# Altium schematic sheet Sensor.SchDoc
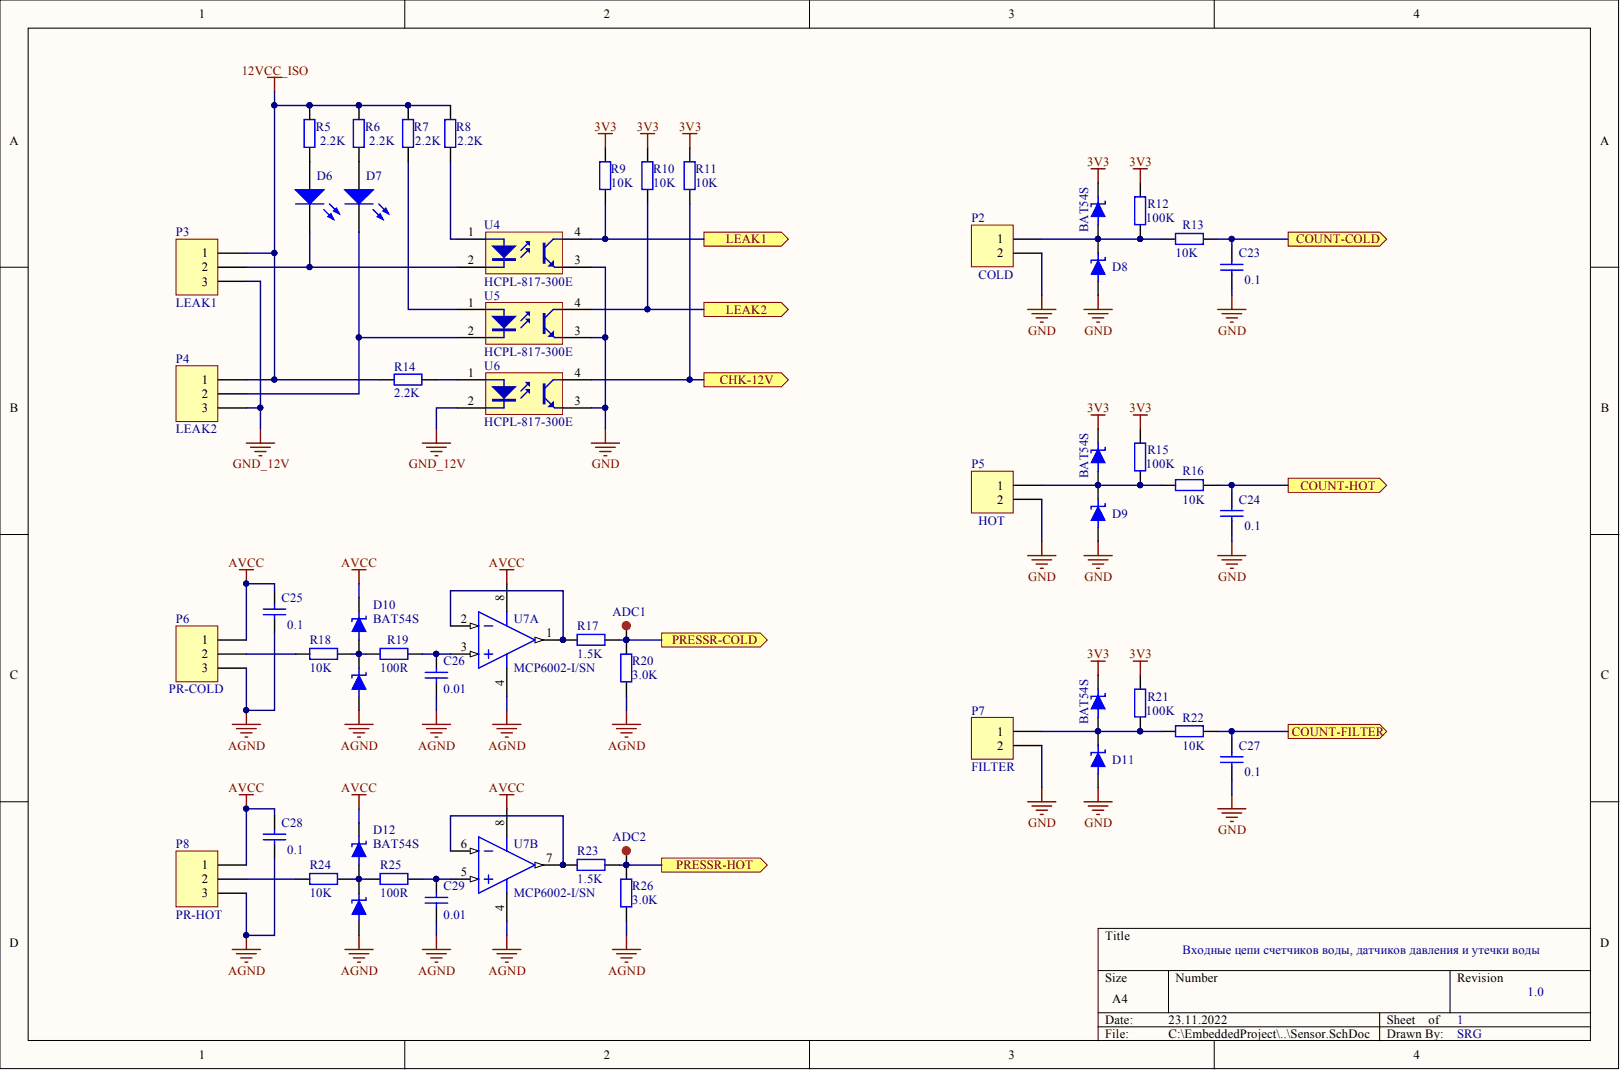
[diagram p0: Sensor.SchDoc]

## Parts
ADC1  Control mark  [Control mark]  (value not drawn)
ADC2  Control mark  [Control mark]  (value not drawn)
C23  value 0.1  [C1206]  (hidden comment: Cap)
C24  value 0.1  [C1206]  (hidden comment: Cap)
C25  value 0.1  [C1206]  (hidden comment: Cap)
C26  value 0.01  [C1206]  (hidden comment: Cap)
C27  value 0.1  [C1206]  (hidden comment: Cap)
C28  value 0.1  [C1206]  (hidden comment: Cap)
C29  value 0.01  [C1206]  (hidden comment: Cap)
D6  ALR1  [LED1206 - RED]  (value not drawn)
D7  ALR2  [LED1206 - RED]  (value not drawn)
D8  BAT54S  [SOT23]
D9  BAT54S  [SOT23]
D10  BAT54S  [SOT23]
D11  BAT54S  [SOT23]
D12  BAT54S  [SOT23]
P2  COLD  [KF141V-2]
P3  LEAK1  [KF141V-3]
P4  LEAK2  [KF141V-3]
P5  HOT  [KF141V-2]
P6  PR-COLD  [KF141V-3]
P7  FILTER  [KF141V-2]
P8  PR-HOT  [KF141V-3]
R5  value 2.2K  [R1206]  (hidden comment: Res2)
R6  value 2.2K  [R1206]  (hidden comment: Res2)
R7  value 2.2K  [R1206]  (hidden comment: Res2)
R8  value 2.2K  [R1206]  (hidden comment: Res2)
R9  value 10K  [R1206]  (hidden comment: Res2)
R10  value 10K  [R1206]  (hidden comment: Res2)
R11  value 10K  [R1206]  (hidden comment: Res2)
R12  value 100K  [R1206]  (hidden comment: Res2)
R13  value 10K  [R1206]  (hidden comment: Res2)
R14  value 2.2K  [R1206]  (hidden comment: Res2)
R15  value 100K  [R1206]  (hidden comment: Res2)
R16  value 10K  [R1206]  (hidden comment: Res2)
R17  value 1.5K  [R1206]  (hidden comment: Res2)
R18  value 10K  [R1206]  (hidden comment: Res2)
R19  value 100R  [R1206]  (hidden comment: Res2)
R20  value 3.0K  [R1206]  (hidden comment: Res2)
R21  value 100K  [R1206]  (hidden comment: Res2)
R22  value 10K  [R1206]  (hidden comment: Res2)
R23  value 1.5K  [R1206]  (hidden comment: Res2)
R24  value 10K  [R1206]  (hidden comment: Res2)
R25  value 100R  [R1206]  (hidden comment: Res2)
R26  value 3.0K  [R1206]  (hidden comment: Res2)
U4  HCPL-817-300E  [4-SMD]
U5  HCPL-817-300E  [4-SMD]
U6  HCPL-817-300E  [4-SMD]
U7  MCP6002-I/SN  [SOIC-SN8_N]  (parts A,B)

## Ports
CHK-12V, COUNT-COLD, COUNT-FILTER, COUNT-HOT, LEAK1, LEAK2, PRESSR-COLD, PRESSR-HOT

## Nets
3V3: D8.2 D9.2 D11.2 R9.2 R10.2 R11.2 R12.1 R15.1 R21.1
12VCC_ISO: P3.1 P4.1 R5.2 R6.2 R7.2 R8.2 R14.2
AGND: C25.2 C26.1 C28.2 C29.1 D10.1 D12.1 P6.3 P8.3 R20.1 R26.1 U7.4
AVCC: C25.1 C28.1 D10.2 D12.2 P6.1 P8.1 U7.8
GND: C23.2 C24.2 C27.2 D8.1 D9.1 D11.1 P2.2 P5.2 P7.2 U4.3 U5.3 U6.3
GND_12V: P3.3 P4.3 U6.2
CHK-12V: R11.1 U6.4 ; port CHK-12V
COUNT-COLD: C23.1 R13.1 ; port COUNT-COLD
COUNT-FILTER: C27.1 R22.1 ; port COUNT-FILTER
COUNT-HOT: C24.1 R16.1 ; port COUNT-HOT
LEAK1: R9.1 U4.4 ; port LEAK1
LEAK2: R10.1 U5.4 ; port LEAK2
PRESSR-COLD: ADC1.1 R17.2 R20.2 ; port PRESSR-COLD
PRESSR-HOT: ADC2.1 R23.2 R26.2 ; port PRESSR-HOT
NetC26_2: C26.2 R19.2 U7.3
NetC29_2: C29.2 R25.2 U7.5
NetD6_1: D6.1 R5.1
NetD6_2: D6.2 P3.2 U4.2
NetD7_1: D7.1 R6.1
NetD7_2: D7.2 P4.2 U5.2
NetD8_3: D8.3 P2.1 R12.2 R13.2
NetD9_3: D9.3 P5.1 R15.2 R16.2
NetD10_3: D10.3 R18.2 R19.1
NetD11_3: D11.3 P7.1 R21.2 R22.2
NetD12_3: D12.3 R24.2 R25.1
NetP6_2: P6.2 R18.1
NetP8_2: P8.2 R24.1
NetR7_1: R7.1 U5.1
NetR8_1: R8.1 U4.1
NetR14_1: R14.1 U6.1
NetR17_1: R17.1 U7.1 U7.2
NetR23_1: R23.1 U7.6 U7.7

## Library data of the parts
C23-C25 C27 C28 = Cap: SMD 1206 Capasitor Body 3.2 x 1.6 mm; Component Kind: Standard; LatestRevisionDate: 17-Jul-2002; LatestRevisionNote: Re-released for DXP Platform.; Library Name: Miscellaneous Devices.IntLib; PackageReference: RAD-0.3; Published: 8-Jun-2000; Signal Integrity: Cap; Simulation: CAP; Publisher: Altium Limited
C26 C29 = Cap: SMD 1206 Capasitor Body 3.2 x 1.6 mm; PartNumber: GRM31CR72A104K; DeliveryTime: 7; Component Kind: Standard; Library Name: Miscellaneous Devices.IntLib; Price: 10.00; Signal Integrity: Cap; Simulation: CAP
D6 D7 = LED0: SMD LED; Value: RED; Component Kind: Standard; LatestRevisionDate: 08-Jul-2005; LatestRevisionNote: Mech Layer 1 removed.; Library Name: Miscellaneous Devices.IntLib; PackageReference: LED-0; Published: 8-Jun-2000; Simulation: LED0; Publisher: Altium Limited
D8-D12 = BAT54S: Schottky Barrier Double Diode; Component Kind: Standard; Library Name: Diodes.IntLib; Price: 0.08; Signal Integrity: D; Simulation: BAT54S; Value: BAT54S
P2 P5 P7 = Header 2: Header, 2-Pin; Value: KF141V-2; Component Kind: Standard; LatestRevisionDate: 17-Jul-2002; LatestRevisionNote: Re-released for DXP Platform.; Library Name: Miscellaneous Connectors.IntLib; Signal Integrity: Connector; Publisher: Altium Limited
P3 P4 P6 P8 = Header 3: Header, 3-Pin; Value: KF141V-3; Component Kind: Standard; LatestRevisionDate: 17-Jul-2002; LatestRevisionNote: Re-released for DXP Platform.; Library Name: Miscellaneous Connectors.IntLib; Signal Integrity: Connector; Publisher: Altium Limited
R5-R8 R12-R16 R21 R22 = Res2: SMD 1206 Resistor Body 3.2 x 1.6 mm; Component Kind: Standard; LatestRevisionDate: 17-Jul-2002; LatestRevisionNote: Re-released for DXP Platform.; Library Name: Miscellaneous Devices.IntLib; PackageReference: AXIAL-0.4; Published: 8-Jun-2000; Signal Integrity: Res; Simulation: RESISTOR; Publisher: Altium Limited
  R13 R16 R22: PackageDescription: Axial Device, Thru-Hole; 2 Leads; 0.4 in Pin Spacing
R9-R11 R17-R20 R23-R26 = Res2: SMD 1206 Resistor Body 3.2 x 1.6 mm; PartNumber: RC1206JK-07220RL; DeliveryTime: 7; Component Kind: Standard; Library Name: Miscellaneous Devices.IntLib; Price: 0.90; Signal Integrity: Res; Simulation: RESISTOR
U4-U6 = HCPL-817-300E: 4-Pin Phototransistor Optocoupler; Component Kind: Standard; DatasheetVersion: Nov-2003; LatestRevisionDate: 04-Mar-2005; LatestRevisionNote: Sch pins 3 & 4 Swapped.; Library Name: Schlib1.SchLib; PackageReference: DIP-4; Published: 24-Mar-1999; Value: HCPL-817-300E; Publisher: Altium Limited
U7 = MCP6002-I/SN: 1 MHz, Low-Power Operational Amplifier, 8-Pin SOIC, Industrial Temperature; Manufacturer: Microchip; Code_IPC: SOIC127P600-8; Code_JEDEC: MS-012; Component Kind: Standard; ComponentLink1Description: Manufacturer URL; ComponentLink1URL: http://www.microchip.com/; ComponentLink2Description: Datasheet; ComponentLink2URL: http://ww1.microchip.com/downloads/en/DeviceDoc/21733j.pdf; ComponentLink3Description: Package Specification; ComponentLink3URL: http://www.microchip.com/stellent/groups/techpub_sg/documents/packagingspec/en012702.pdf; DatasheetVersion: revJ, Nov-2009; Library Name: Microchip Linear.IntLib; Mounting Technology: Surface Mount; PackageDescription: 8-Lead Plastic Small Outline (SN) - Narrow, 3.90mm Body [SOIC]; PackageReference: SOIC-SN8; PackageVersion: revBB, Aug-2009; PartNumber: MCP6002-I/SN; Supplier 1: Digi-Key; Supplier 2: Farnell; Supplier 3: Newark; Supplier 4: Mouser; Supplier Part Number 1: MCP6002-I/SN-ND; Supplier Part Number 2: 1292246; Supplier Part Number 3: 63K5672; Supplier Part Number 4: 579-MCP6002-I/SN; Value: MCP6002-I/SN
ADC1 ADC2 = Control mark: Component Kind: Standard; Library Name: Schlib1.SchLib
